# Altium schematic sheet Core_S3.SchDoc
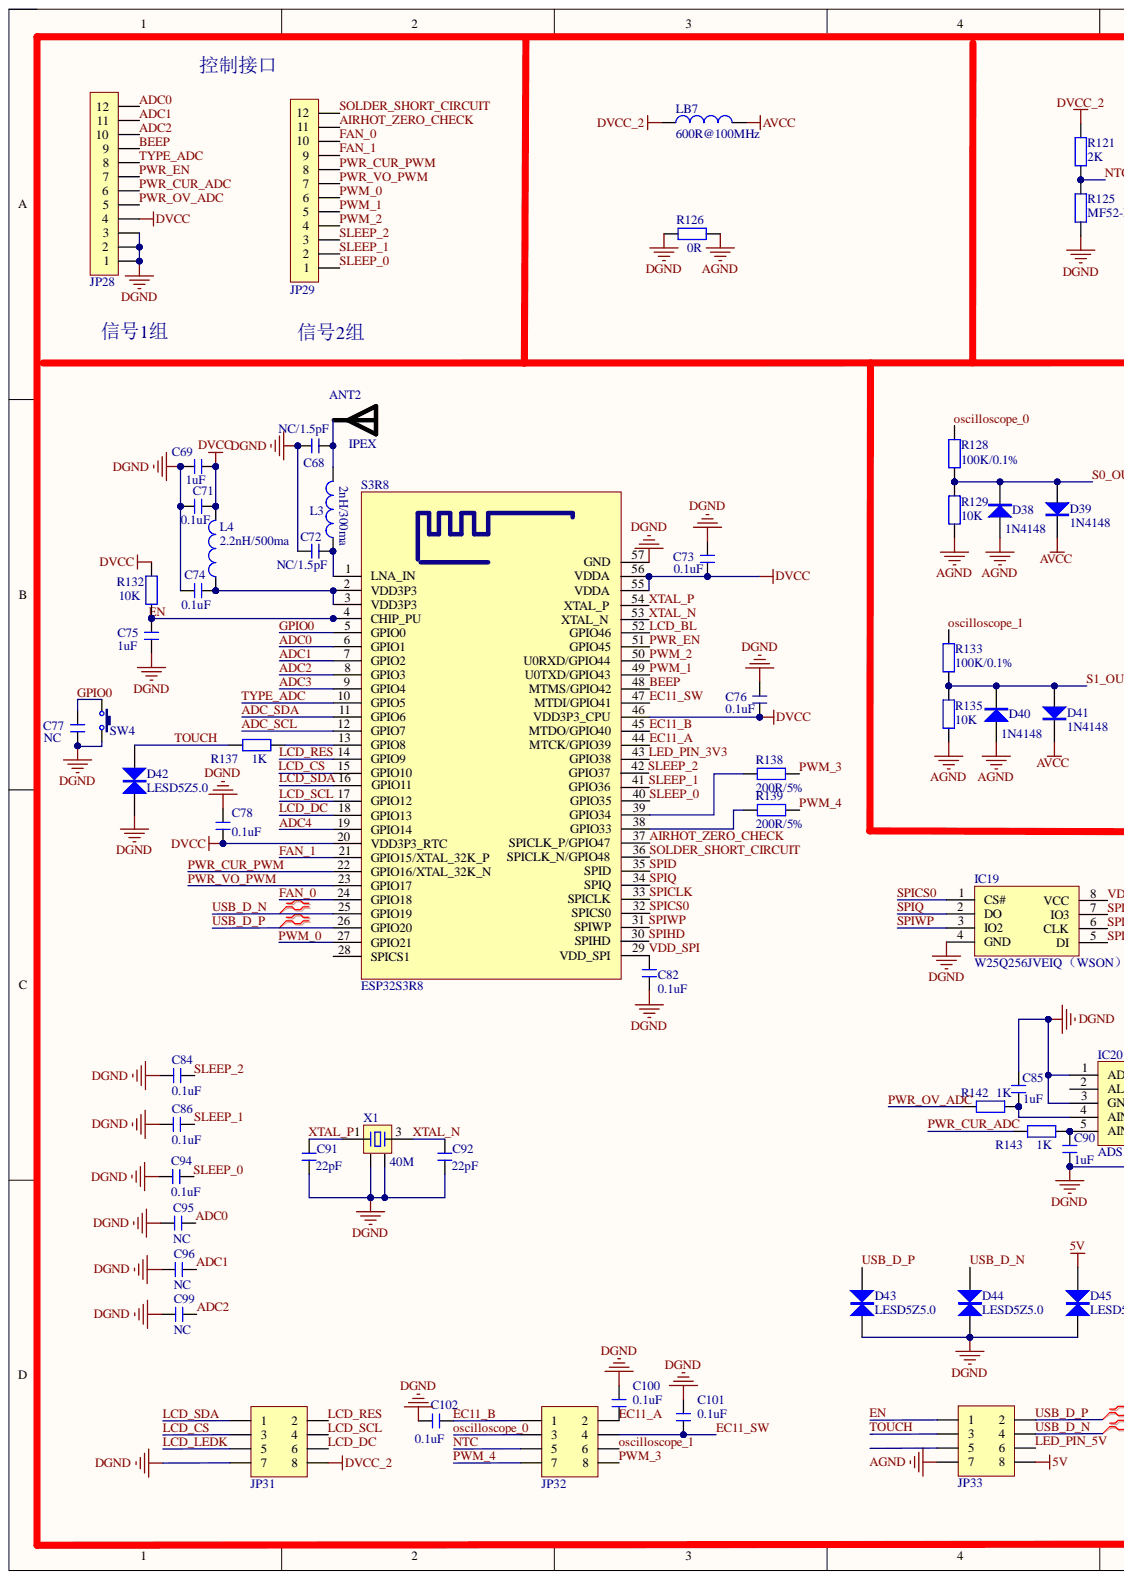
[diagram p0: Core_S3.SchDoc, left]
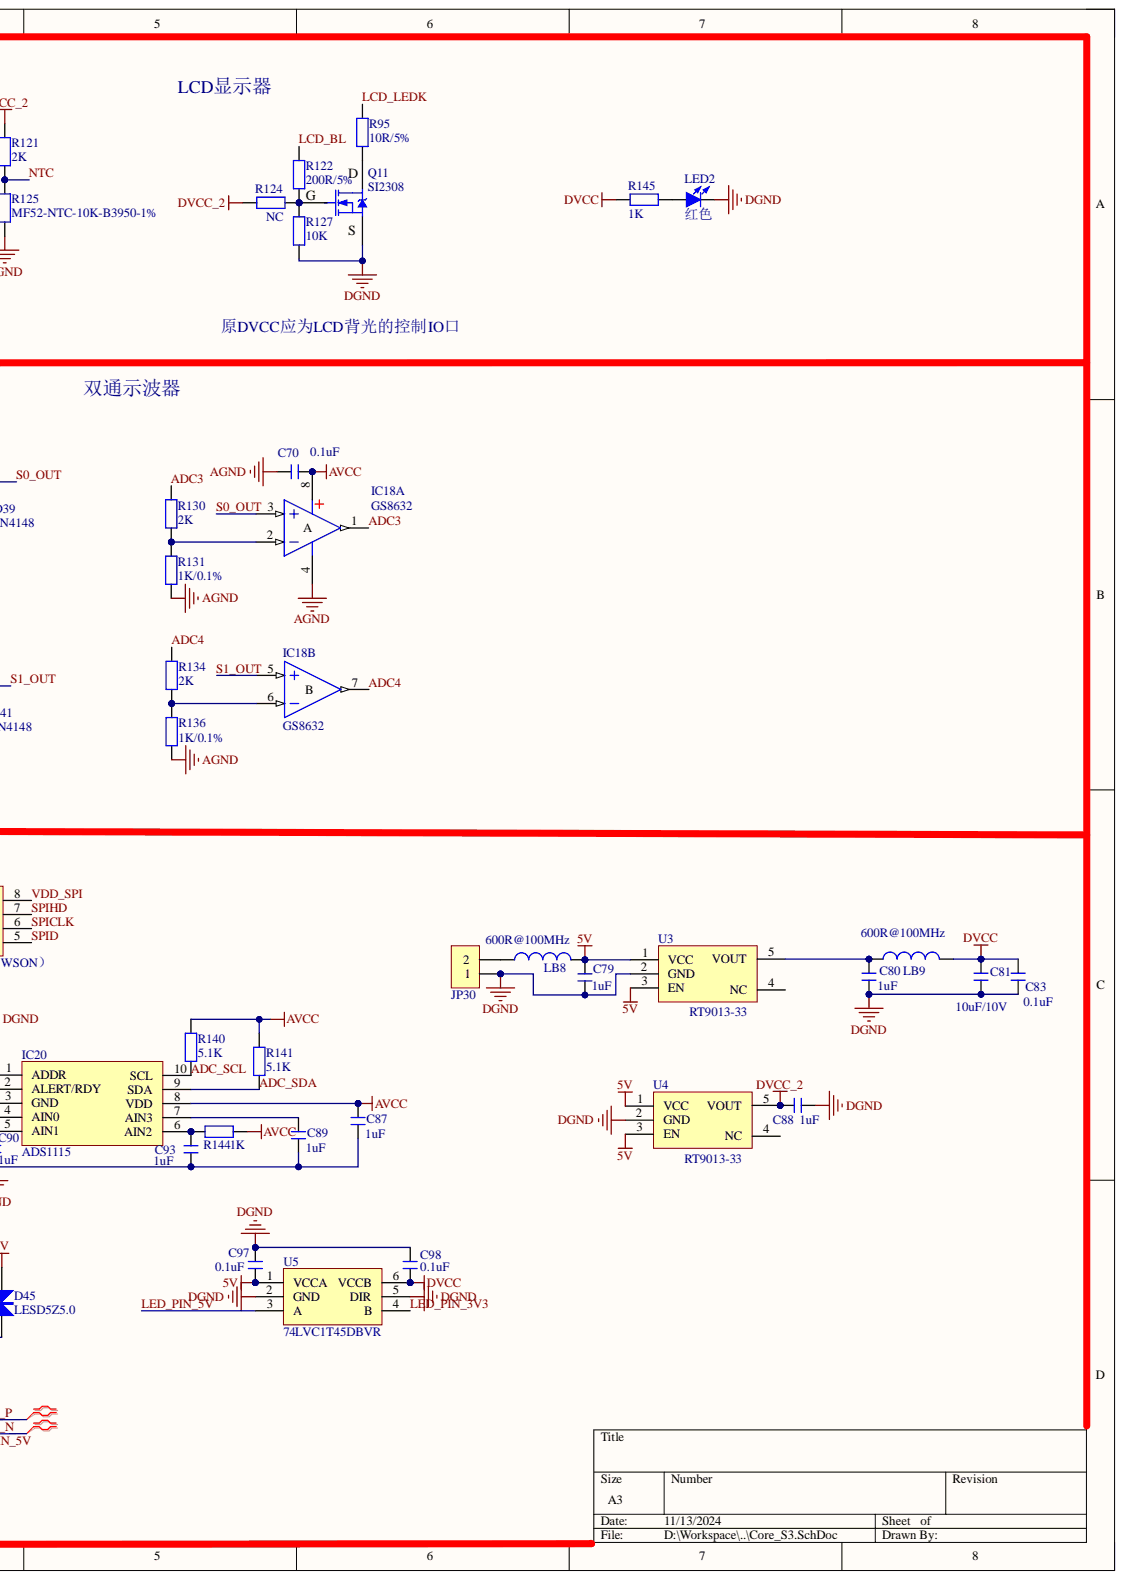
[diagram p1: Core_S3.SchDoc, right]

## Parts
ANT2  IPEX  [IPEX]
C68  NC/1.5pF  [C 0402_L]
C69  1uF  [C 0603]
C70  0.1uF  [C 0402_L]
C71  0.1uF  [C 0402_L]
C72  NC/1.5pF  [C 0402_L]
C73  0.1uF  [C 0402_L]
C74  0.1uF  [C 0402_L]
C75  1uF  [C 0603]
C76  0.1uF  [C 0402_L]
C77  NC  [C 0402_L]
C78  0.1uF  [C 0402_L]
C79  1uF  [C 0603]
C80  1uF  [C 0603]
C81  10uF/10V  [C 0603]
C82  0.1uF  [C 0402_L]
C83  0.1uF  [C 0402_L]
C84  0.1uF  [C 0402_L]
C85  1uF  [C 0402_L]
C86  0.1uF  [C 0402_L]
C87  1uF  [C 0603]
C88  1uF  [C 0603]
C89  1uF  [C 0402_L]
C90  1uF  [C 0402_L]
C91  22pF  [C 0402_L]
C92  22pF  [C 0402_L]
C93  1uF  [C 0402_L]
C94  0.1uF  [C 0402_L]
C95  NC  [C 0402_L]
C96  NC  [C 0402_L]
C97  0.1uF  [C 0402_L]
C98  0.1uF  [C 0402_L]
C99  NC  [C 0402_L]
C100  0.1uF  [C 0402_L]
C101  0.1uF  [C 0402_L]
C102  0.1uF  [C 0402_L]
D38  1N4148  [SOD-323]
D39  1N4148  [SOD-323]
D40  1N4148  [SOD-323]
D41  1N4148  [SOD-323]
D42  LESD5Z5.0  [SOD-523]
D43  LESD5Z5.0  [SOD-523]
D44  LESD5Z5.0  [SOD-523]
D45  LESD5Z5.0  [SOD-523]
IC18  GS8632  [SOP8_N]  (parts A,B)
IC19  W25Q256JVEIQ（WSON）  [SOIC-8_L5.3-W5.3-P1.27-LS8.0-BL]
IC20  ADS1115  [VSSOP-10_L3.0-W3.0-P0.50-LS5.0-BL]
JP28  FPC0.5-12P  [FPC0.5 2H-WS-12P]  (value not drawn)
JP29  FPC0.5-12P  [FPC0.5 2H-WS-12P]  (value not drawn)
JP30  XH2.54-LS-2P  [XH2.54-LS-2P]  (value not drawn)
JP31  直插1.27mm 2x4P  [HDR1.27-LI-2x4P]  (value not drawn)
JP32  直插1.27mm 2x4P  [HDR1.27-LI-2x4P]  (value not drawn)
JP33  直插1.27mm 2x4P  [HDR1.27-LI-2x4P]  (value not drawn)
L3  2nH/300ma  [L 0402_L]
L4  2.2nH/500ma  [L 0603_L]
LB7  600R@100MHz  [FB 0805_L]
LB8  600R@100MHz  [FB 0805_L]
LB9  600R@100MHz  [FB 0805_L]
LED2  红色  [LED 0603R]
Q11  SI2308  [SOT23-3L]
R95  10R/5%  [R 0603]
R121  2K  [R 0603]
R122  200R/5%  [R 0603]
R124  NC  [R 0603]
R125  MF52-NTC-10K-B3950-1%  [R-NTC-MF52]
R126  0R  [R 0603]
R127  10K  [R 0603]
R128  100K/0.1%  [R 0603]
R129  10K  [R 0603]
R130  2K  [R 0603]
R131  1K/0.1%  [R 0603]
R132  10K  [R 0603]
R133  100K/0.1%  [R 0603]
R134  2K  [R 0603]
R135  10K  [R 0603]
R136  1K/0.1%  [R 0603]
R137  1K  [R 0603]
R138  200R/5%  [R 0603]
R139  200R/5%  [R 0603]
R140  5.1K  [R 0603]
R141  5.1K  [R 0603]
R142  1K  [R 0603]
R143  1K  [R 0603]
R144  1K  [R 0603]  (value not drawn)
R145  1K  [R 0603]
S3R8  ESP32S3R8  [WIFIM_ESP32-S3-QFN]
SW4  3*4*2  [TSW 3*4-S-W]  (value not drawn)
U3  RT9013-33  [SOT23-5N]
U4  RT9013-33  [SOT23-5N]
U5  74LVC1T45DBVR  [SOT23-6N]
X1  40M  [OSC 3225-4P]

## Nets
5V: C79.2 C97.2 D45.2 JP33.8 LB8.2 U3.1(VCC) U3.3(EN) U4.1(VCC) U4.3(EN) U5.1(VCCA)
AGND: C70.1 D38.1 D40.1 IC18.4(A) JP33.7 R126.2 R129.1 R131.1 R135.1 R136.1
AVCC: C70.2 C87.2 D39.2 D41.2 IC18.8 IC20.8(VDD) LB7.2 R140.2 R141.2 R144.1
DGND: C68.2 C69.2 C71.2 C72.2 C73.2 C74.2 C75.1 C76.2 C77.1 C78.1 C79.1 C80.1 C81.1 C82.2 C83.1 C84.1 C85.2 C86.1 C87.1 C88.1 C89.1 C90.1 C91.1 C92.1 C93.1 C94.1 C95.1 C96.1 C97.1 C98.1 C99.1 C100.2 C101.2 C102.2 D42.1 D43.1 D44.1 D45.1 IC19.4(GND) IC20.1(ADDR) IC20.3(GND) JP28.1 JP28.2 JP28.3 JP30.1 JP31.7 LED2.2 Q11.2 R125.1 R126.1 R127.1 S3R8.57(GND) SW4.2 U3.2(GND) U4.2(GND) U5.2(GND) U5.5(DIR) X1.2 X1.4
DVCC: C69.1 C71.1 C73.1 C76.1 C78.2 C81.2 C83.2 C98.2 JP28.4 L4.2 LB9.2 R132.2 R145.1 S3R8.20(VDD3P3_RTC) S3R8.46(VDD3P3_CPU) S3R8.55(VDDA) S3R8.56(VDDA) U5.6(VCCB)
DVCC_2: C88.2 JP31.8 LB7.1 R121.2 R124.1 U4.5(VOUT)
ADC0: C95.2 JP28.12 S3R8.6(GPIO1)
ADC1: C96.2 JP28.11 S3R8.7(GPIO2)
ADC2: C99.2 JP28.10 S3R8.8(GPIO3)
ADC3: IC18.1 R130.2 S3R8.9(GPIO4)
ADC4: IC18.7 R134.2 S3R8.19(GPIO14)
ADC_SCL: IC20.10(SCL) R140.1 S3R8.12(GPIO7)
ADC_SDA: IC20.9(SDA) R141.1 S3R8.11(GPIO6)
AIRHOT_ZERO_CHECK: JP29.11 S3R8.37(SPICLK_P/GPIO47)
BEEP: JP28.9 S3R8.48(MTMS/GPIO42)
EC11_A: C100.1 JP32.2 S3R8.44(MTCK/GPIO39)
EC11_B: C102.1 JP32.1 S3R8.45(MTDO/GPIO40)
EC11_SW: C101.1 JP32.4 S3R8.47(MTDI/GPIO41)
EN: C75.2 JP33.1 R132.1 S3R8.4(CHIP_PU)
FAN_0: JP29.10 S3R8.24(GPIO18)
FAN_1: JP29.9 S3R8.21(GPIO15/XTAL_32K_P)
GPIO0: C77.2 S3R8.5(GPIO0) SW4.1
LCD_BL: R122.2 S3R8.52(GPIO46)
LCD_CS: JP31.3 S3R8.15(GPIO10)
LCD_DC: JP31.6 S3R8.18(GPIO13)
LCD_LEDK: JP31.5 R95.2
LCD_RES: JP31.2 S3R8.14(GPIO9)
LCD_SCL: JP31.4 S3R8.17(GPIO12)
LCD_SDA: JP31.1 S3R8.16(GPIO11)
LED_PIN_3V3: S3R8.43(GPIO38) U5.4(B)
LED_PIN_5V: JP33.6 U5.3(A)
NTC: JP32.5 R121.1 R125.2
oscilloscope_0: JP32.3 R128.2
oscilloscope_1: JP32.6 R133.2
PWM_0: JP29.6 S3R8.27(GPIO21)
PWM_1: JP29.5 S3R8.49(U0TXD/GPIO43)
PWM_2: JP29.4 S3R8.50(U0RXD/GPIO44)
PWM_3: JP32.8 R138.2
PWM_4: JP32.7 R139.2
PWR_CUR_ADC: JP28.6 R143.2
PWR_CUR_PWM: JP29.8 S3R8.22(GPIO16/XTAL_32K_N)
PWR_EN: JP28.7 S3R8.51(GPIO45)
PWR_OV_ADC: JP28.5 R142.2
PWR_VO_PWM: JP29.7 S3R8.23(GPIO17)
S0_OUT: D38.2 D39.1 IC18.3 R128.1 R129.2
S1_OUT: D40.2 D41.1 IC18.5 R133.1 R135.2
SLEEP_0: C94.2 JP29.1 S3R8.40(GPIO35)
SLEEP_1: C86.2 JP29.2 S3R8.41(GPIO36)
SLEEP_2: C84.2 JP29.3 S3R8.42(GPIO37)
SOLDER_SHORT_CIRCUIT: JP29.12 S3R8.36(SPICLK_N/GPIO48)
SPICLK: IC19.6(CLK) S3R8.33(SPICLK)
SPICS0: IC19.1(CS#) S3R8.32(SPICS0)
SPID: IC19.5(DI) S3R8.35(SPID)
SPIHD: IC19.7(IO3) S3R8.30(SPIHD)
SPIQ: IC19.2(DO) S3R8.34(SPIQ)
SPIWP: IC19.3(IO2) S3R8.31(SPIWP)
TOUCH: D42.2 JP33.3 R137.2
TYPE_ADC: JP28.8 S3R8.10(GPIO5)
USB_D_N: D44.2 JP33.4 S3R8.25(GPIO19)
USB_D_P: D43.2 JP33.2 S3R8.26(GPIO20)
VDD_SPI: C82.1 IC19.8(VCC) S3R8.29(VDD_SPI)
XTAL_N: C92.2 S3R8.53(XTAL_N) X1.3
XTAL_P: C91.2 S3R8.54(XTAL_P) X1.1
NetANT2_1: ANT2.1 C68.1 L3.2
NetC72_1: C72.1 L3.1 S3R8.1(LNA_IN)
NetC74_1: C74.1 L4.1 S3R8.2(VDD3P3) S3R8.3(VDD3P3)
NetC80_2: C80.2 LB9.1 U3.5(VOUT)
NetC85_1: C85.1 IC20.4(AIN0) R142.1
NetC89_2: C89.2 IC20.7(AIN3)
NetC90_2: C90.2 IC20.5(AIN1) R143.1
NetC93_2: C93.2 IC20.6(AIN2) R144.2
NetIC18_2: IC18.2 R130.1 R131.2
NetIC18_6: IC18.6 R134.1 R136.2
NetJP30_2: JP30.2 LB8.1
NetLED2_1: LED2.1 R145.2
NetQ11_1: Q11.1 R122.1 R124.2 R127.2
NetQ11_3: Q11.3 R95.1
NetR137_1: R137.1 S3R8.13(GPIO8)
NetR138_1: R138.1 S3R8.39(GPIO34)
NetR139_1: R139.1 S3R8.38(GPIO33)

Not connected: IC20 2(ALERT/RDY); JP33 5; S3R8 28(SPICS1); U3 4(NC); U4 4(NC)

## Library data of the parts
ANT2 = ANT: IPEX天线座; BOM名称: DC稳压芯片; 版权归属: 源创客; Component Kind: Standard; 学习资源: www.ooocke.com; Library Name: SuperHeat.SCHLIB
C68-C102 = C: 无极性贴片电容; 版权归属: 源创客; BOM名称: 无极性贴片电容; Component Kind: Standard; 学习资源: www.ooocke.com; Library Name: SuperHeat.SCHLIB
D38-D41 = 1N4148: 高速开关二极管; BOM名称: 高速开关二极管; Component Kind: Standard; Library Name: SuperHeat.SCHLIB
D42-D45 = ESD: ESD静电保护; BOM名称: 高速开关二极管; Component Kind: Standard; Library Name: SuperHeat.SCHLIB
IC18 = SGM8632: 轨到轨双路运放; Component Kind: Standard; Library Name: SuperHeat.SCHLIB
IC19 = W25Q128JVSIQ: 133MHz高速Flash; BOM名称: 串口转USB; Component Kind: Standard; Library Name: SuperHeat.SCHLIB
IC20 = ADS1115: 16位ADC芯片; BOM名称: 串口转USB; Component Kind: Standard; Library Name: SuperHeat.SCHLIB
JP28 JP29 = FPC-12P: FPC连接器; BOM名称: FPC连接器; Component Kind: Standard; Library Name: SuperHeat.SCHLIB
JP30 = Header 2: 2P接插件; 版权归属: 源创客; BOM名称: 2P接插件; Component Kind: Standard; 学习资源: www.ooocke.com; Library Name: SuperHeat.SCHLIB
JP31-JP33 = Header 4X2: 4*2P接插件; BOM名称: 4*2P接插件; Component Kind: Standard; Library Name: SuperHeat.SCHLIB
L3 L4 = L: 小功率贴片电感; BOM名称: 小功率贴片电感; Component Kind: Standard; Library Name: SuperHeat.SCHLIB
LB7-LB9 = LB: 磁珠; BOM名称: 磁珠; Component Kind: Standard; Library Name: SuperHeat.SCHLIB
LED2 = LED-SMD: 贴片LED; BOM名称: 贴片LED; Component Kind: Standard; Library Name: SuperHeat.SCHLIB
Q11 = SI2302: N沟道场效应管; 版权归属: 源创客; BOM名称: N沟道场效应管; Component Kind: Standard; 学习资源: www.ooocke.com; Library Name: SuperHeat.SCHLIB
R95 R121 R122 R124 R126-R145 = R: 贴片电阻; 版权归属: 源创客; BOM名称: 贴片电阻; Component Kind: Standard; 学习资源: www.ooocke.com; Library Name: SuperHeat.SCHLIB
R125 = R-NTC: 直插热敏电阻; BOM名称: 贴片电阻; 版权归属: 源创客; Component Kind: Standard; 学习资源: www.ooocke.com; Library Name: SuperHeat.SCHLIB
S3R8 = ESP32S3FN8: 主控芯片 带FN、FH均不能用; Component Kind: Standard; Library Name: SuperHeat.SCHLIB
SW4 = TSW 3x4: 3x4轻触开关; BOM名称: 3x6轻触开关; 版权归属: 源创客; Component Kind: Standard; 学习资源: www.ooocke.com; Library Name: SuperHeat.SCHLIB
U3 U4 = RT9013: LDO稳压3.3V; BOM名称: DC降压芯片; Component Kind: Standard; Library Name: SuperHeat.SCHLIB
U5 = 74LVC1T45DBVR: 双电源总线收发器; BOM名称: USB控制器; Component Kind: Standard; Library Name: SuperHeat.SCHLIB
X1 = XTAL-4P: 4脚无源晶振; 负载电容: CL20pF; BOM名称: 4脚无源晶振; Component Kind: Standard; Library Name: SuperHeat.SCHLIB
